# Altium schematic sheet bargraph.SchDoc
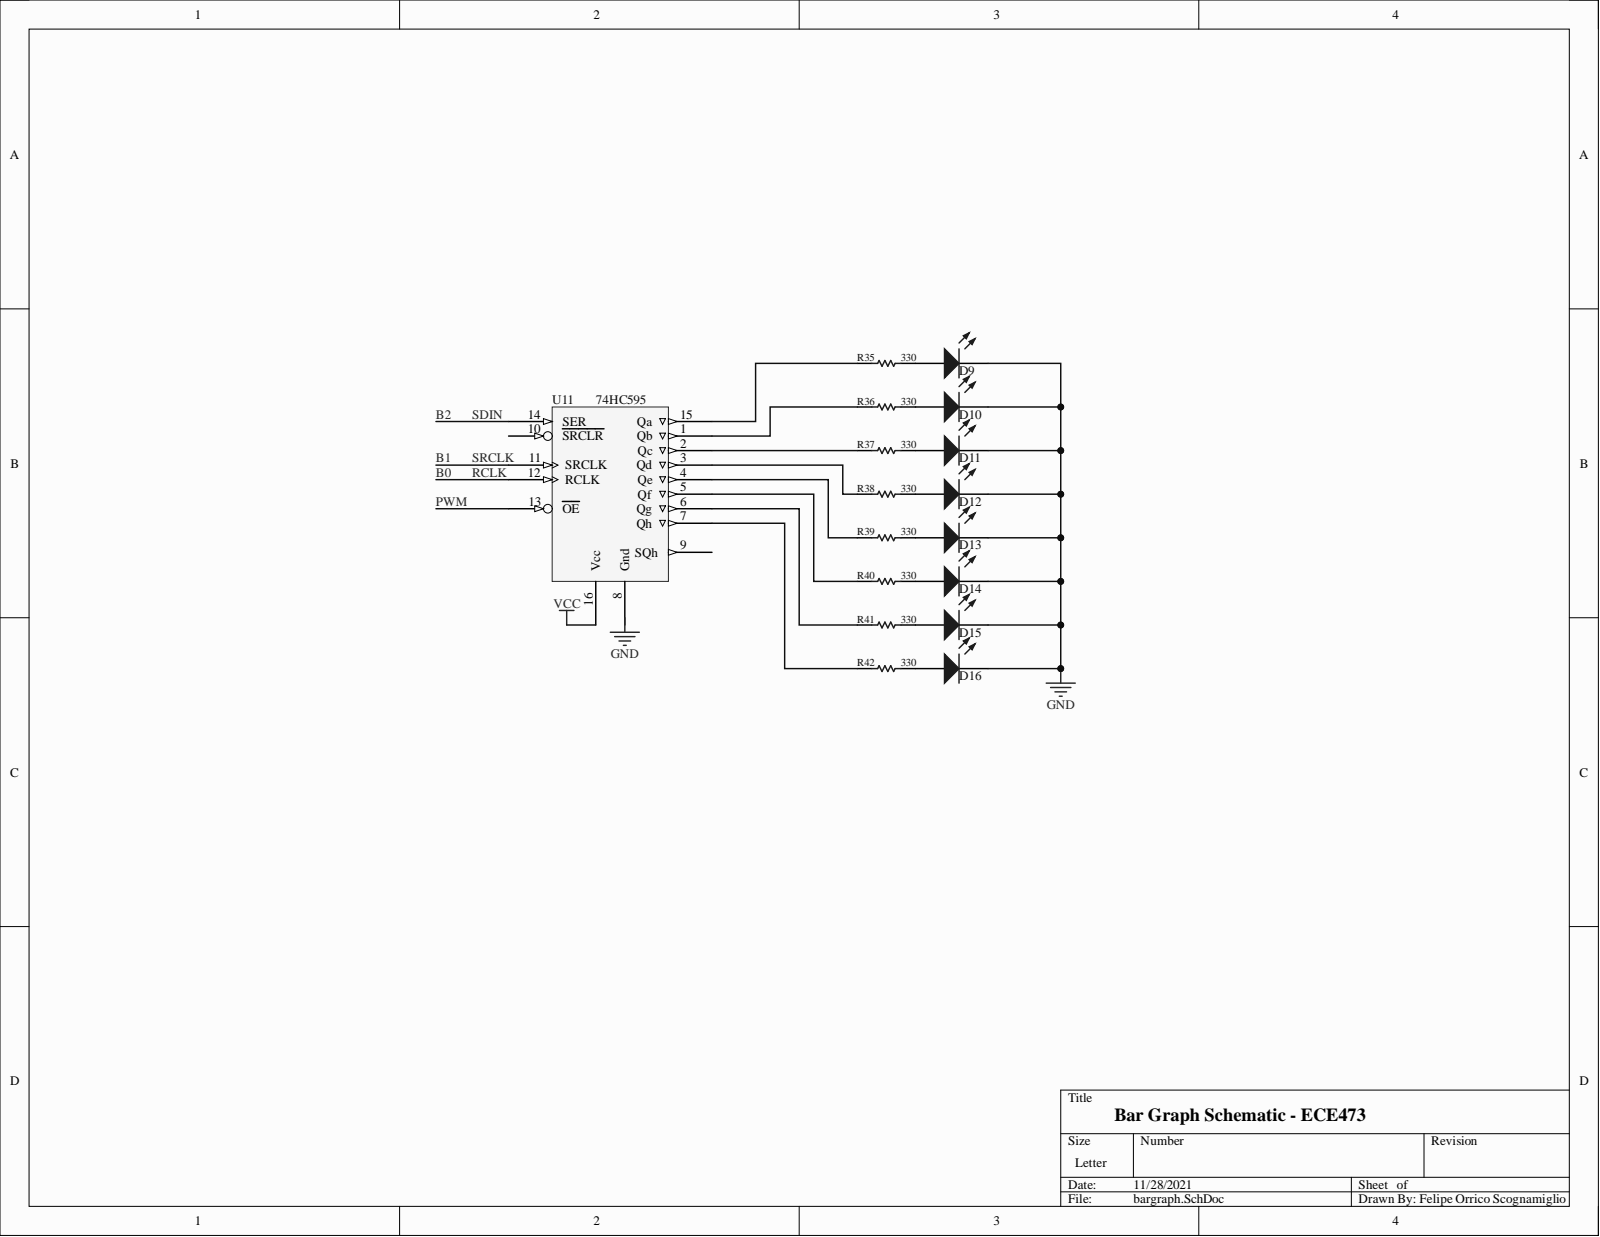
[diagram p0: bargraph.SchDoc]

## Parts
D9  Dialight 598-8270-107F  [PCB-2vlaskzzfjgohp60yj1w-1]  (value not drawn)
D10  Dialight 598-8270-107F  [PCB-2vlaskzzfjgohp60yj1w-1]  (value not drawn)
D11  Dialight 598-8270-107F  [PCB-2vlaskzzfjgohp60yj1w-1]  (value not drawn)
D12  Dialight 598-8270-107F  [PCB-2vlaskzzfjgohp60yj1w-1]  (value not drawn)
D13  Dialight 598-8270-107F  [PCB-2vlaskzzfjgohp60yj1w-1]  (value not drawn)
D14  Dialight 598-8270-107F  [PCB-2vlaskzzfjgohp60yj1w-1]  (value not drawn)
D15  Dialight 598-8270-107F  [PCB-2vlaskzzfjgohp60yj1w-1]  (value not drawn)
D16  Dialight 598-8270-107F  [PCB-2vlaskzzfjgohp60yj1w-1]  (value not drawn)
R35  330  [PCB-q466dezs08uszq8774n0-1]
R36  330  [PCB-q466dezs08uszq8774n0-1]
R37  330  [PCB-q466dezs08uszq8774n0-1]
R38  330  [PCB-q466dezs08uszq8774n0-1]
R39  330  [PCB-q466dezs08uszq8774n0-1]
R40  330  [PCB-q466dezs08uszq8774n0-1]
R41  330  [PCB-q466dezs08uszq8774n0-1]
R42  330  [PCB-q466dezs08uszq8774n0-1]
U11  74HC595  [PCB-5wzj7lcqhzs45rcumufu-1]

## Nets
GND: D9.2 D10.2 D11.2 D12.2 D13.2 D14.2 D15.2 D16.2
VCC: U11.16(Vcc)
B0: U11.12(RCLK)
B1: U11.11(SRCLK)
B2: U11.14(SER)
PWM: U11.13(OE)
NetD9_1: D9.1 R35.2
NetD10_1: D10.1 R36.2
NetD11_1: D11.1 R37.2
NetD12_1: D12.1 R38.2
NetD13_1: D13.1 R39.2
NetD14_1: D14.1 R40.2
NetD15_1: D15.1 R41.2
NetD16_1: D16.1 R42.2
NetR35_1: R35.1 U11.15(Qa)
NetR36_1: R36.1 U11.1(Qb)
NetR37_1: R37.1 U11.2(Qc)
NetR38_1: R38.1 U11.3(Qd)
NetR39_1: R39.1 U11.4(Qe)
NetR40_1: R40.1 U11.5(Qf)
NetR41_1: R41.1 U11.6(Qg)
NetR42_1: R42.1 U11.7(Qh)

Not connected: U11 8(Gnd) 9(SQh) 10(SRCLR)

## Library data of the parts
D9-D16 = CMP-0c18c51f68fad3df-1: LED Uni-Color Green 2-Pin Chip 1206(3216Metric) T/R; Case/Package (SI): 3216; Forward Voltage: 2.00 V; Lead-Free Status: Lead Free; Lens Type: Non-Diffused; Luminous Intensity: 20.0 mcd; Viewing Angle: 140 °; Wavelength: 570 nm; Case/Package: 1206; Color: Green; Component Kind: Standard; Library Name: My Personal Space; Manufacturer Part Number: 598-8270-107F; Mounting Style: Surface Mount, Vertical; Number of Pins: 2; Octopart URL: https://octopart.com/598-8270-107f-dialight-868740; OctopartID: 0c18c51f68fad3df; Operating Temperature: 85.0 °C (max); Packaging: Cut Tape (CT), Tape & Reel (TR); REACH SVHC Compliance: No SVHC; RoHS: Compliant; Size-Height: 700 µm; Size-Length: 3.20 mm; Size-Width: 1.40 mm
R35-R42 = CMP-e82f23a0358237e8-1: RC Series 0201 0.05 W 1 kOhms 5% ±200 ppm/°C SMT Thick Film Chip Resistor; Manufacturer Part Number: RC0201JR-071KL; Lead-Free Status: Lead Free; Power Rating: 50.0 mW; Resistance: 1.00 kΩ; Voltage Rating (DC): 25.0 V; Case/Package: 0201; Component Kind: Standard; Library Name: My Personal Space; Mounting Style: Surface Mount; Number of Pins: 2; Octopart URL: http://octopart.com/rc0201jr-071kl-yageo-42334411; OctopartID: e82f23a0358237e8; Packaging: Tape & Reel; REACH SVHC Compliance: No SVHC; Resistance Tolerance: ±5%; RoHS: Compliant; Size-Length: 600 µm
U11 = CMP-f97c307bb62a26bb-1: Shift Register Single 8-Bit Serial to Serial/Parallel 16-Pin SOIC Tube; Manufacturer Part Number: CD74HC595M; Frequency: 42.0 MHz; Lead-Free Status: Lead Free; Number of Outputs: 9; Output Current Drive: -1.00 mA; Propagation Delay Max (tpd): 32.0 ns; Supply Voltage (DC): 2.00 V to 6.00 V; Voltage Nodes: 2.00 V, 5.00 V, 6.00 V; Case/Package: PDIP, SOIC; Component Kind: Standard; Library Name: My Personal Space; Lifecycle Status: Active; Mounting Style: Surface Mount; Number of Pins: 16; Octopart URL: https://octopart.com/cd74hc595m-texas+instruments-879152; OctopartID: f97c307bb62a26bb; Operating Temperature: -55.0 °C to 125 °C; REACH SVHC Compliance: No SVHC; RoHS: Compliant
